# Altium schematic sheet FPGA_BD2.SchDoc
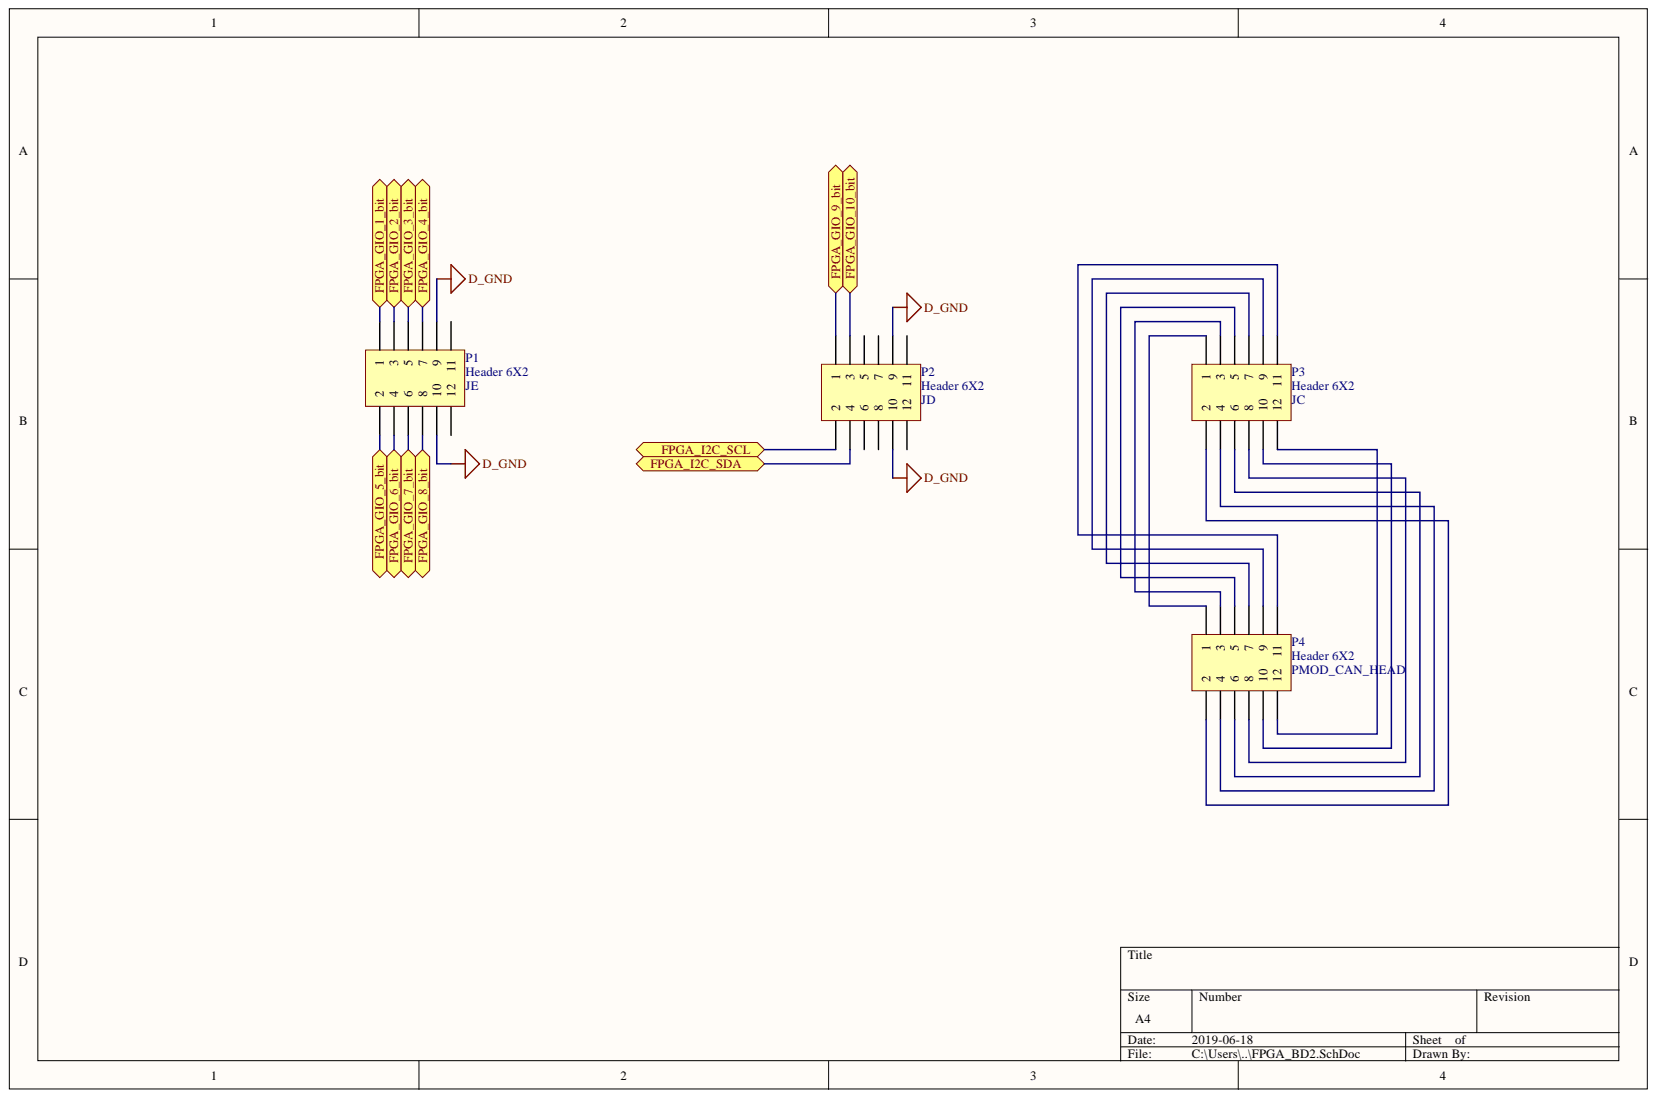
[diagram p0: FPGA_BD2.SchDoc]

## Parts
P1  Header 6X2  [HDR2X6]
P2  Header 6X2  [HDR2X6]
P3  Header 6X2  [HDR2X6]
P4  Header 6X2  [HDR2X6]

## Ports
FPGA_GIO_1_BIT, FPGA_GIO_2_BIT, FPGA_GIO_3_BIT, FPGA_GIO_4_BIT, FPGA_GIO_5_BIT, FPGA_GIO_6_BIT, FPGA_GIO_7_BIT, FPGA_GIO_8_BIT, FPGA_GIO_9_BIT, FPGA_GIO_10_BIT, FPGA_I2C_SCL, FPGA_I2C_SDA

## Nets
D_GND: P1.9 P1.10 P2.9 P2.10
FPGA_I2C_SCL: P2.2 ; port FPGA_I2C_SCL
FPGA_I2C_SDA: P2.4 ; port FPGA_I2C_SDA
NetP1_1: P1.1 ; port FPGA_GIO_1_BIT
NetP1_2: P1.2 ; port FPGA_GIO_5_BIT
NetP1_3: P1.3 ; port FPGA_GIO_2_BIT
NetP1_4: P1.4 ; port FPGA_GIO_6_BIT
NetP1_5: P1.5 ; port FPGA_GIO_3_BIT
NetP1_6: P1.6 ; port FPGA_GIO_7_BIT
NetP1_7: P1.7 ; port FPGA_GIO_4_BIT
NetP1_8: P1.8 ; port FPGA_GIO_8_BIT
NetP2_1: P2.1 ; port FPGA_GIO_9_BIT
NetP2_3: P2.3 ; port FPGA_GIO_10_BIT
NetP3_1: P3.1 P4.1
NetP3_2: P3.2 P4.2
NetP3_3: P3.3 P4.3
NetP3_4: P3.4 P4.4
NetP3_5: P3.5 P4.5
NetP3_6: P3.6 P4.6
NetP3_7: P3.7 P4.7
NetP3_8: P3.8 P4.8
NetP3_9: P3.9 P4.9
NetP3_10: P3.10 P4.10
NetP3_11: P3.11 P4.11
NetP3_12: P3.12 P4.12

Not connected: P1 11 12; P2 5 6 7 8 11 12

## Library data of the parts
P1-P4 = Header 6X2: Header, 6-Pin, Dual row; Component Kind: Standard; LatestRevisionDate: 17-Jul-2002; LatestRevisionNote: Re-released for DXP Platform.; Library Name: Miscellaneous Connectors.IntLib; Signal Integrity: Connector; Publisher: Altium Limited
